# Altium schematic sheet CONNECTORS.SchDoc(CONNECTORS)
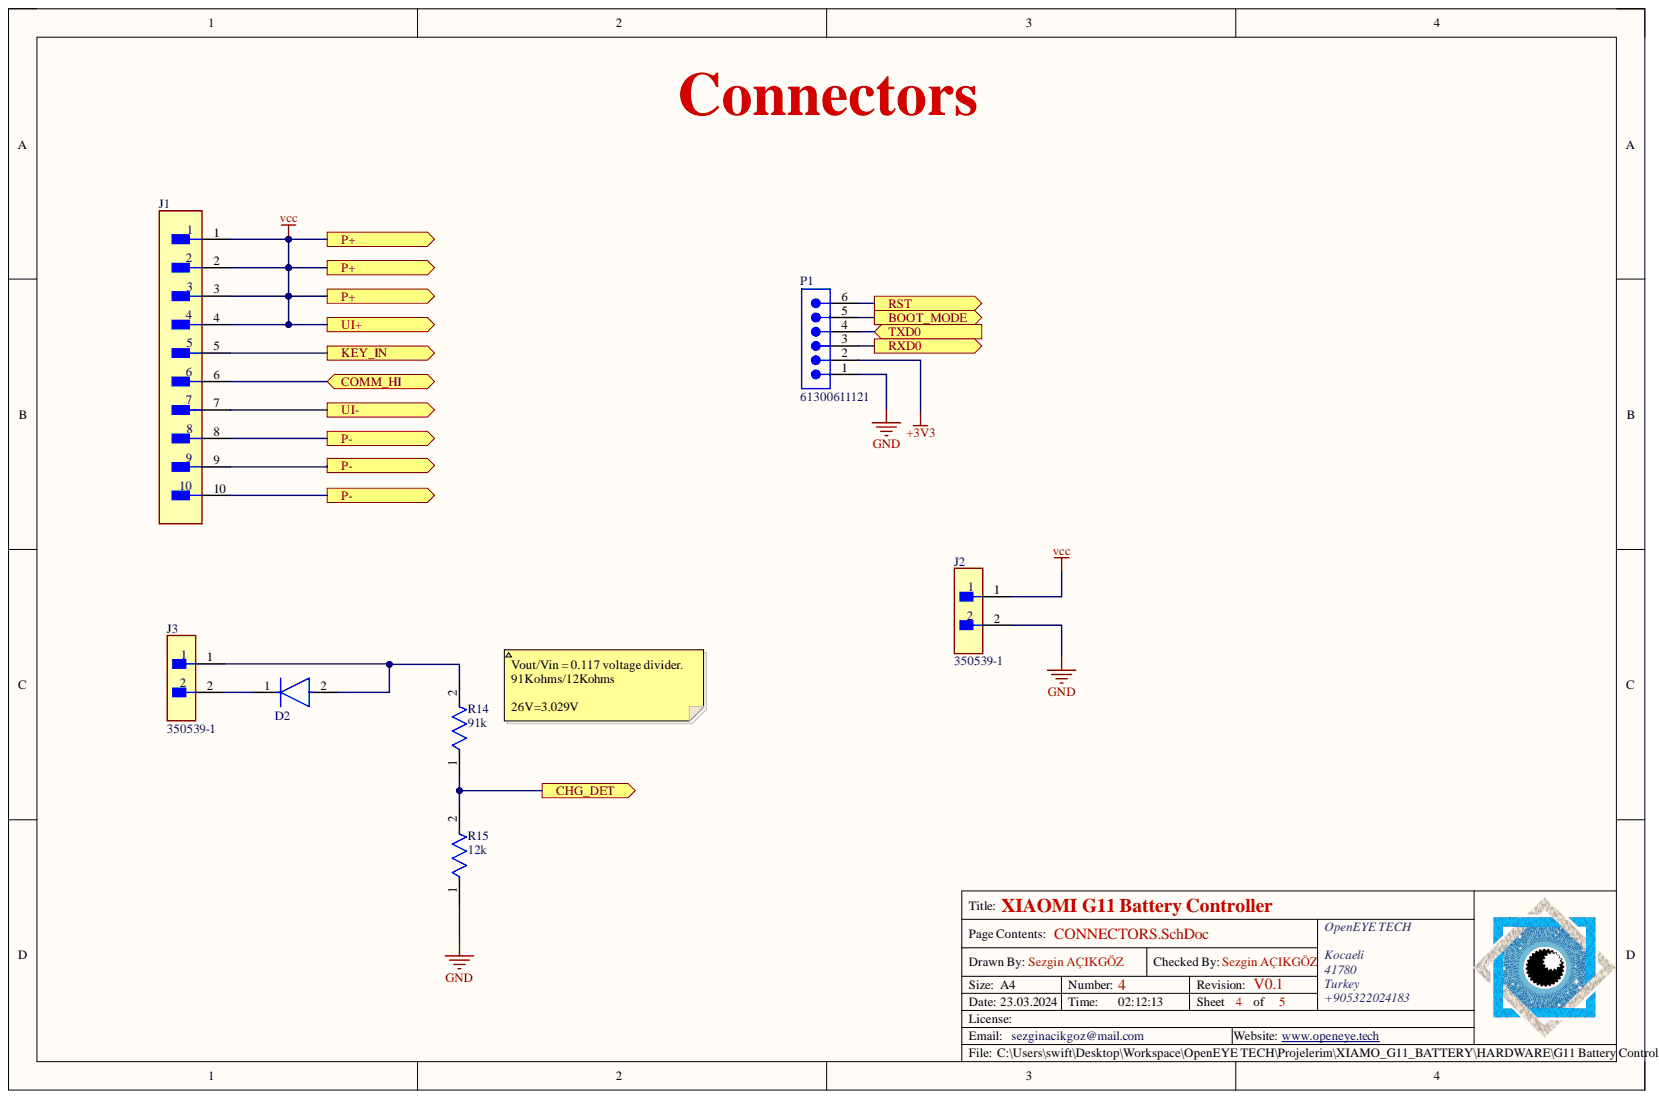
[diagram p0: CONNECTORS.SchDoc]

## Parts
D2  ES2DA-13-F  [FP-SMA-MFG]  (value not drawn)
J1  S10B-PH-K-S(LF)(SN)  [FP-S10B-PH-K-S_LF_SN-MFG]  (value not drawn)
J2  350539-1  [FP-350539-1-MFG]
J3  350539-1  [FP-350539-1-MFG]
P1  61300611121  [61300611121]
R14  91k  [FP-RP731J-MFG]
R15  12k  [FP-RP731J-MFG]

## Ports
BOOT_MODE, CHG_DET, COMM_HI, KEY_IN, P+, P-, RST, RXD0, TXD0, UI+, UI-

## Nets
+3V3: P1.2
GND: J2.2 P1.1 R15.1
vcc: J1.1 J1.2 J1.3 J1.4 J2.1
BOOT_MODE: P1.5 ; port BOOT_MODE
CHG_DET: R14.1 R15.2 ; port CHG_DET
COMM_HI: J1.6 ; port COMM_HI
KEY_IN: J1.5 ; port KEY_IN
P-: J1.8 J1.9 J1.10 ; port P-
RST: P1.6 ; port RST
RXD0: P1.3 ; port RXD0
TXD0: P1.4 ; port TXD0
UI-: J1.7 ; port UI-
NetD2_1: D2.1 J3.2
NetD2_2: D2.2 J3.1 R14.2

## Library data of the parts
D2 = CMP-12791-000014-1: 200V 2A Surface Mount Super-Fast Rectifier DO-214AC; Manufacturer: Diodes Inc.; Capacitance: 25pF; Max Junction Temp: +150°C; Max Surge Forward Current: 50A; Peak Reverse Current: 5uA; Standoff Height: 0.05mm; Value: 25pF; Automotive: No; Category: Diode; Component Kind: Standard; ComponentLink2Description: Datasheet; ComponentLink2URL: https://www.diodes.com/assets/Datasheets/ds14002.pdf; Device Class L1: Discrete Semiconductors; Device Class L2: Diodes; Device Class L3: Rectifier Diodes; Digikey Description: DIODE GEN PURP 200V 2A SMA; Footprint Url: https://www.diodes.com/assets/Package-Files/SMA.pdf; Forward Current: 2A; Height: 2.4mm; LCSC Part #: C5140013; Lead Free: Yes; Library Name: Altium Content Vault; Manufacturer Part Number: ES2DA-13-F; Max Repetitive Reverse Voltage: 200V; Mouser Description: Rectifiers RECTIFIER SUPER FAST "F" LEAD FREE; Octopart: https://octopart.com/es2da-13-f-diodes+inc.-328903; Package: SMA; Reverse Voltage: 200V; RoHS: TRUE; Temperature: -55°C to +150°C; Temperature Range High: +150°C; Temperature Range Low: -55°C
J1 = CMP-17439-000377-2: CONN HEADER R/A 10POS 2MM; Contact Resistance: 20mR; Current Rating: 2A; Gender: Male; Max Voltage Rating (AC): 100V; Number of Contacts: 10; Color: Natural; Component Kind: Standard; Connector Type: Header; Contact Finish - Mating: TIN; Contact Material: Brass; Contact Mating Length: 0.13inch; Contact Plating: Copper; Contact Shape: Square; Contact Type: Male Pin; Depth: 7.6mm; Fastening Type: Detent; Flammability Rating: UL94 V-0; Height: 9.4mm; Housing Color: White; Housing Material: Polyamide; Lead Free: Lead Free; Length: 21.9mm; Library Name: Altium Content Vault; Max Current Rating: 2A; Max Operating Temperature: 85°C; Min Operating Temperature: -25°C; Mount: Through Hole; Number of Pins: 10; Number of Positions: 10; Number of Rows: 1; Orientation: Right Angle; Packaging: Bulk; Pitch: 2mm; Series: PH; Termination: Solder; Voltage Rating: 100V; Width: 4.5mm; Insulation Color: Natural; Insulation Material: Nylon
J2 J3 = CMP-03369-000078-1: CONN HEADER 2POS VERT .200 TIN; Manufacturer: TE Connectivity; Conn Gender: Male; Conn Orientation: Vertical; Current Rating: 12A; Number Of Contacts: 2; Pitch: 5.08mm; Standoff Height: 0.025inch; Voltage Rating: 250V; Automotive: No; Category: Conn; Component Kind: Standard; ComponentLink2Description: Datasheet; ComponentLink2URL: https://www.te.com/usa-en/product-350539-1.html?te_bu=Cor&te_type=other&te_campaign=oct_glo_cor-oct-global-oth-prtnr-fy19-oct-octo-buy-bom_sma-298_2&elqCampaignId=35216; Contact Material: Gold,Nickel; Device Class L1: Connectors; Device Class L2: Headers and Wire Housings; Device Class L3: unset; Digikey Description: CONN HEADER VERT 2POS 5.08MM; Height: 0.41inch; Lead Free: Yes; Library Name: Altium Content Vault; Manufacturer Part Number: 350539-1; Mouser Description: Pin & Socket Connectors CONN PCM 2 POS TIN; Number Of Rows: 1; Octopart: https://octopart.com/350539-1-te+connectivity-46510628; Package: 350539-1; RoHS: TRUE; Temperature: -55°C to +105°C; Temperature Range High: +105°C; Temperature Range Low: -55°C
P1 = CMP-1502-01070-2: NexarPartId: 20084590; Connector Type: Male Pin; Contact Resistance: 20mΩ; Current Rating: 3A; Gender: Male; Number of Contacts: 6; Operating Supply Voltage: 250V; Pitch: 2.54mm; Color: Black; Component Kind: Standard; Contact Gender: Male; Contact Material: Copper; Contact Mating Length: 5.9944mm; Contact Plating: Gold; Height: 8.89mm; Housing Material: PBT; Lead Free: Lead Free; Lead Pitch: 2.54mm; Library Name: Altium Content Vault; Max Operating Temperature: 105°C; Min Operating Temperature: -40°C; Mount: Through Hole; Number of Pins: 6; Number of Positions: 6; Number of Rows: 1; Orientation: Vertical; Packaging: Bulk; REACH SVHC: Unknown; RoHS: Compliant; Termination: Through Hole; Voltage Rating: 250V
R14 = CMP-03211-008596-1: TE CONNECTIVITY / HOLSWORTHY SMD Chip Resistor, Thin Film, 8.45Ω, 50 V, 0603 [1608 Metric], 100 mW, 0.1% , RP73 Series; Manufacturer Part Number: RP73D1J8R45BTDG; Power Rating: 0.1W; Resistance: 91kΩ; Temperature Coefficient: 15ppm/°C; Value: 91kΩ; Voltage Rating: 75V; Automotive: No; Case/Package: 603; Category: Res; Component Kind: Standard; ComponentLink2Description: Datasheet; ComponentLink2URL: https://www.te.com/commerce/DocumentDelivery/DDEController?Action=srchrtrv&DocNm=1773272&DocType=DS&DocLang=English; Composition: Thin Film; Device Class L1: Passive Components; Device Class L2: Resistors; Device Class L3: Chip SMD Resistors; Height: 0.55mm; Lead Free: Yes; Library Name: Altium Content Vault; Manufacturer: TE Connectivity; Octopart: https://octopart.com/rp73d1j8r45btdg-te+connectivity-42630912; octopart_url: https://octopart.com/rp73d1j8r45btdg-te+connectivity-42630912; Package: 603; packaging: cut tape; RoHS: TRUE; Series: RP73; Temperature: -55°C to +105°C; Temperature Range High: +105°C; Temperature Range Low: -55°C; Tolerance: 0.1%; Ipc Land Pattern Name: RESC15580X45
R15 = CMP-03211-008596-1: TE CONNECTIVITY / HOLSWORTHY SMD Chip Resistor, Thin Film, 8.45Ω, 50 V, 0603 [1608 Metric], 100 mW, 0.1% , RP73 Series; Manufacturer Part Number: RP73D1J8R45BTDG; Power Rating: 0.1W; Resistance: 12kΩ; Temperature Coefficient: 15ppm/°C; Value: 12kΩ; Voltage Rating: 75V; Automotive: No; Case/Package: 603; Category: Res; Component Kind: Standard; ComponentLink2Description: Datasheet; ComponentLink2URL: https://www.te.com/commerce/DocumentDelivery/DDEController?Action=srchrtrv&DocNm=1773272&DocType=DS&DocLang=English; Composition: Thin Film; Device Class L1: Passive Components; Device Class L2: Resistors; Device Class L3: Chip SMD Resistors; Height: 0.55mm; Lead Free: Yes; Library Name: Altium Content Vault; Manufacturer: TE Connectivity; Octopart: https://octopart.com/rp73d1j8r45btdg-te+connectivity-42630912; octopart_url: https://octopart.com/rp73d1j8r45btdg-te+connectivity-42630912; Package: 603; packaging: cut tape; RoHS: TRUE; Series: RP73; Temperature: -55°C to +105°C; Temperature Range High: +105°C; Temperature Range Low: -55°C; Tolerance: 0.1%; Ipc Land Pattern Name: RESC15580X45
